# Altium schematic sheet 04_Seven_Seg.SchDoc
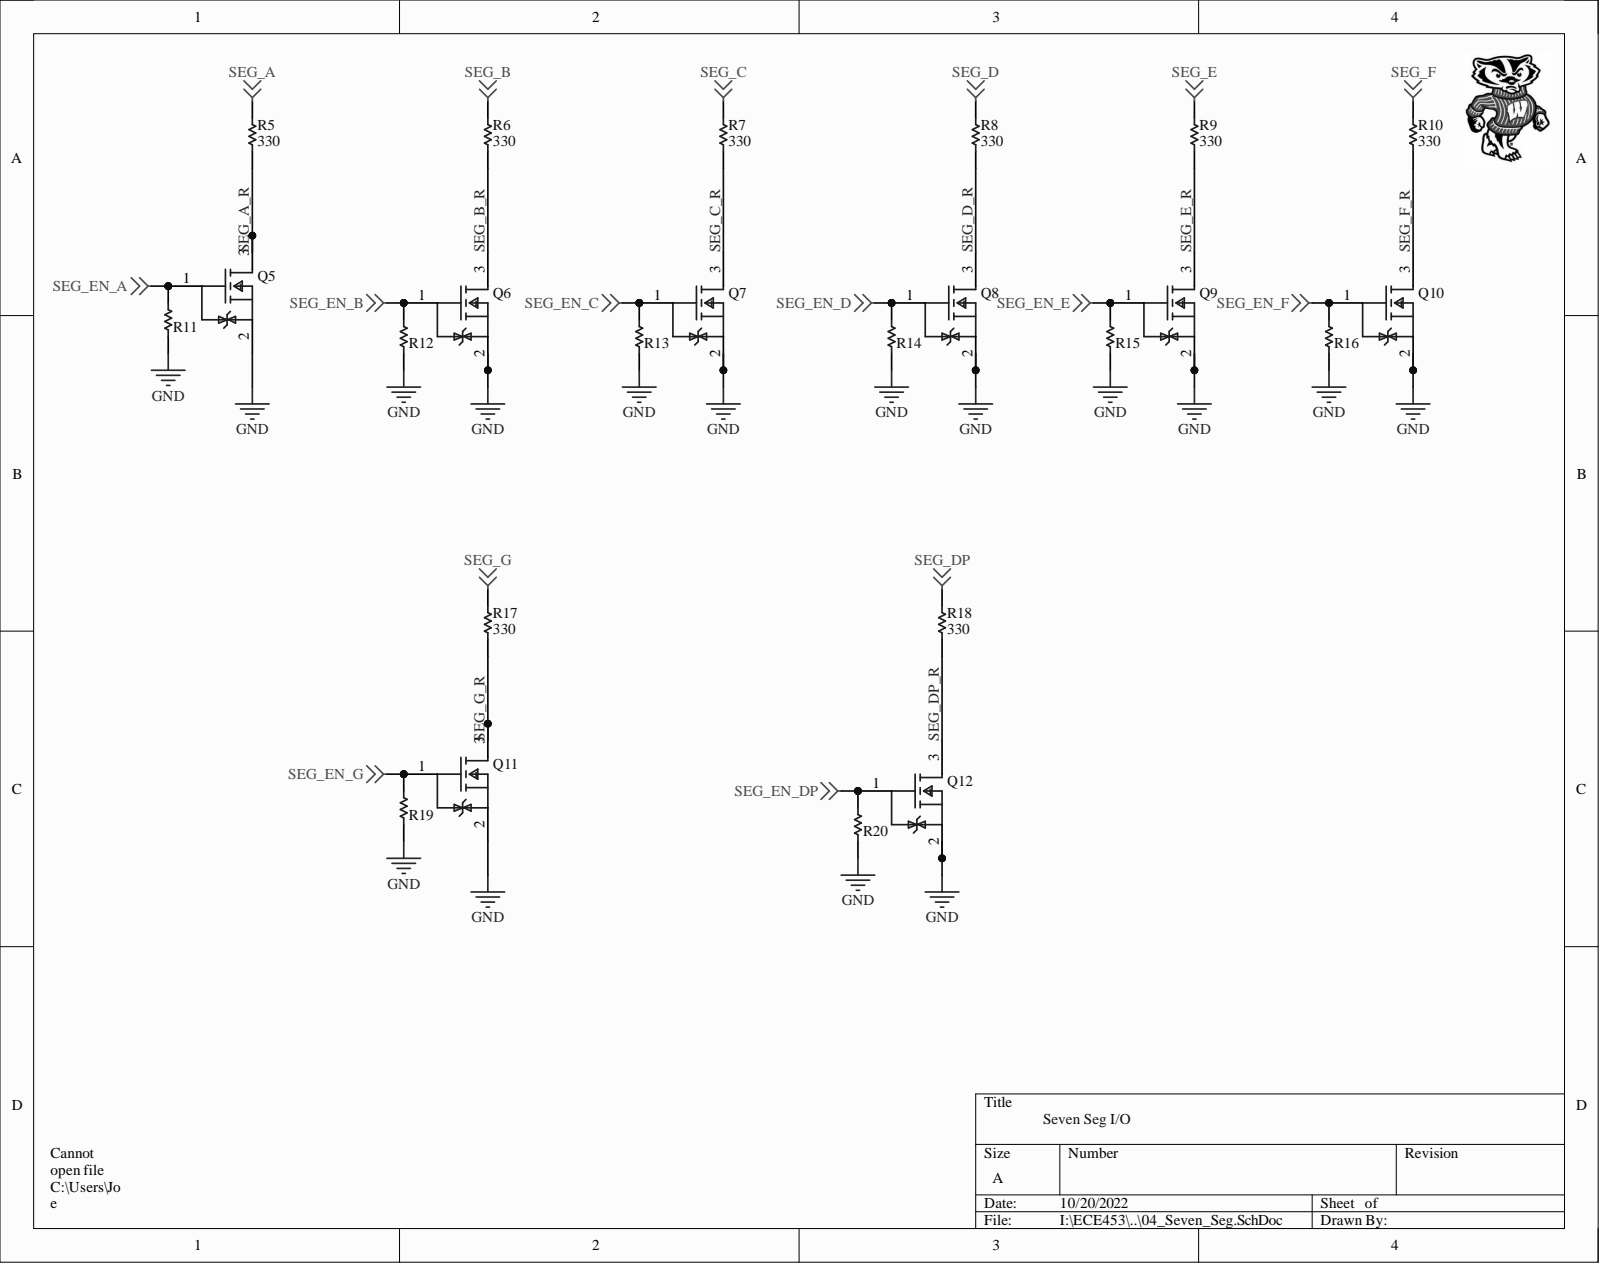
[diagram p0: 04_Seven_Seg.SchDoc]

## Parts
Q5  SSM3K329R,LF  [FP-2-3Z1A-MFG]  (value not drawn)
Q6  SSM3K329R,LF  [FP-2-3Z1A-MFG]  (value not drawn)
Q7  SSM3K329R,LF  [FP-2-3Z1A-MFG]  (value not drawn)
Q8  SSM3K329R,LF  [FP-2-3Z1A-MFG]  (value not drawn)
Q9  SSM3K329R,LF  [FP-2-3Z1A-MFG]  (value not drawn)
Q10  SSM3K329R,LF  [FP-2-3Z1A-MFG]  (value not drawn)
Q11  SSM3K329R,LF  [FP-2-3Z1A-MFG]  (value not drawn)
Q12  SSM3K329R,LF  [FP-2-3Z1A-MFG]  (value not drawn)
R5  330  [RES_0805]
R6  330  [RES_0805]
R7  330  [RES_0805]
R8  330  [RES_0805]
R9  330  [RES_0805]
R10  330  [RES_0805]
R11  RES_0805_K_010.00  [RES_0805]  (value not drawn)
R12  RES_0805_K_010.00  [RES_0805]  (value not drawn)
R13  RES_0805_K_010.00  [RES_0805]  (value not drawn)
R14  RES_0805_K_010.00  [RES_0805]  (value not drawn)
R15  RES_0805_K_010.00  [RES_0805]  (value not drawn)
R16  RES_0805_K_010.00  [RES_0805]  (value not drawn)
R17  330  [RES_0805]
R18  330  [RES_0805]
R19  RES_0805_K_010.00  [RES_0805]  (value not drawn)
R20  RES_0805_K_010.00  [RES_0805]  (value not drawn)

## Nets
GND: Q5.2 Q6.2 Q7.2 Q8.2 Q9.2 Q10.2 Q11.2 Q12.2 R11.1 R12.1 R13.1 R14.1 R15.1 R16.1 R19.1 R20.1
SEG_A: R5.2
SEG_B: R6.2
SEG_C: R7.2
SEG_D: R8.2
SEG_DP: R18.2
SEG_E: R9.2
SEG_EN_A: Q5.1 R11.2
SEG_EN_B: Q6.1 R12.2
SEG_EN_C: Q7.1 R13.2
SEG_EN_D: Q8.1 R14.2
SEG_EN_DP: Q12.1 R20.2
SEG_EN_E: Q9.1 R15.2
SEG_EN_F: Q10.1 R16.2
SEG_EN_G: Q11.1 R19.2
SEG_F: R10.2
SEG_G: R17.2
SEG_A_R: Q5.3 R5.1
SEG_B_R: Q6.3 R6.1
SEG_C_R: Q7.3 R7.1
SEG_D_R: Q8.3 R8.1
SEG_DP_R: Q12.3 R18.1
SEG_E_R: Q9.3 R9.1
SEG_F_R: Q10.3 R10.1
SEG_G_R: Q11.3 R17.1

## Library data of the parts
Q5-Q12 = CMP-35916-000013-1: MOSFET N CH 30V 3.5A 2-3Z1A; Drain to Source Resistance: 290mR; Gate to Source Voltage (Vgs): 12V; Input Capacitance: 123pF; Max Power Dissipation: 1W; Rds On Max: 126mR; Case/Package: SOT-23-3; Component Kind: Standard; Continuous Drain Current (ID): 3.5A; Drain to Source Breakdown Voltage: 30V; Drain to Source Voltage (Vdss): 30V; Library Name: Altium Content Vault; Mount: Surface Mount; Package Quantity: 3000; Packaging: Tape and Reel; Power Dissipation: 1W
R5-R10 R17 R18 = RES_0805_R_330.00: RES 330 OHM 1% 1/8W 0805; Manufacturer 1: Stackpole Electronics; Manufacturer Part Number 1: RMCF0805FT330R; Max Power Dissipation: 125mW; Resistance: 330R; Temperature Coefficient: 100ppm/°C; Case Code (Imperial): 0805; Case Code (Metric): 2012; Case/Package: 0805; Category: Chip SMD Resistors; Component Kind: Standard; ComponentLink1Description: http://components.arrow.com/part/dataview/40963487S9848862N1174?src-supplier=Arrow+Electronics; ComponentLink1URL: http://components.arrow.com/part/dataview/40963487S9848862N1174?src-supplier=Arrow+Electronics; ComponentLink2Description: https://www.seielect.com/catalog/SEI-RMCF_RMCP.pdf?src-supplier=Avnet; ComponentLink2URL: https://www.seielect.com/catalog/SEI-RMCF_RMCP.pdf?src-supplier=Avnet; ComponentLink3Description: https://www.seielect.com/Catalog/SEI-RMCF_RMCP.pdf?src-supplier=Digi-Key; ComponentLink3URL: https://www.seielect.com/Catalog/SEI-RMCF_RMCP.pdf?src-supplier=Digi-Key; ComponentLink4Description: https://www.seielect.com/catalog/SEI-Packaging.pdf?src-supplier=Digi-Key; ComponentLink4URL: https://www.seielect.com/catalog/SEI-Packaging.pdf?src-supplier=Digi-Key; ComponentLink5Description: https://www.verical.com/server-webapp/html/getDatasheet?partID=559884&src-supplier=Verical; ComponentLink5URL: https://www.verical.com/server-webapp/html/getDatasheet?partID=559884&src-supplier=Verical; Construction: Rectangular; Contact Plating: Tin,Matte; Depth: 1.25mm; Features: Automotive AEC-Q200; Height: 0.026inch; Lead Free: Lead Free; Length: 0.079inch; Library Name: team6.SCHLIB; Max Operating Temperature: 155°C; Military Standard: Not; Min Operating Temperature: -55°C; Mount: Surface Mount; Number of Terminations: 2; Packaging: Tape and Reel; Pricing 1: 1=0.10000, 10=0.02700, 100=0.01090, 1000=0.00488, 2500=0.00423 (USD); Radiation Hardening: No; Resistor Type: Thick Film; RoHS: Yes; RoHS Compliant: Yes; Series: RMCF; Stock 1: 205996; Supplier 1: Digi-Key; Supplier Part Number 1: RMCF0805FT330RCT-ND; Tolerance: 1%; Width: 0.049inch
R11-R16 R19 R20 = RES_0805_K_010.00: RES SMD 10K OHM 1% 1/8W 0805; Manufacturer 1: Yageo; Manufacturer Part Number 1: RC0805FR-0710KL; Max Power Dissipation: 125mW; Resistance: 10kR; Temperature Coefficient: 100ppm/°C; Voltage Rating: 150V; Case Code (Imperial): 0805; Case Code (Metric): 2012; Case/Package: 0805; Category: Chip SMD Resistors; Component Kind: Standard; ComponentLink1Description: https://datasheet.ciiva.com/11808/0900766b8106beab-11808151.pdf?src-supplier=RSComponents; ComponentLink1URL: https://datasheet.ciiva.com/11808/0900766b8106beab-11808151.pdf?src-supplier=RSComponents; ComponentLink2Description: https://datasheet.ciiva.com/12669/rc0805-12669688.pdf?src-supplier=Mouser; ComponentLink2URL: https://datasheet.ciiva.com/12669/rc0805-12669688.pdf?src-supplier=Mouser; ComponentLink3Description: https://datasheet.ciiva.com/46447/444164-46447563.pdf?src-supplier=Element14; ComponentLink3URL: https://datasheet.ciiva.com/46447/444164-46447563.pdf?src-supplier=Element14; ComponentLink4Description: https://datasheet.ciiva.com/2456/pyu-r-int-thick-7-2456972.pdf?src-supplier=Digi-Key; ComponentLink4URL: https://datasheet.ciiva.com/2456/pyu-r-int-thick-7-2456972.pdf?src-supplier=Digi-Key; ComponentLink5Description: https://datasheet.ciiva.com/2456/pyu-r-int-thick-7-2456973.pdf?src-supplier=Digi-Key; ComponentLink5URL: https://datasheet.ciiva.com/2456/pyu-r-int-thick-7-2456973.pdf?src-supplier=Digi-Key; ComponentLink6Description: https://datasheet.ciiva.com/2456/pyu-r-int-thick-7-2456974.pdf?src-supplier=Digi-Key; ComponentLink6URL: https://datasheet.ciiva.com/2456/pyu-r-int-thick-7-2456974.pdf?src-supplier=Digi-Key; ComponentLink7Description: https://datasheet.ciiva.com/16747/rc0805-16747087.pdf?src-supplier=Future+Electronics; ComponentLink7URL: https://datasheet.ciiva.com/16747/rc0805-16747087.pdf?src-supplier=Future+Electronics; Construction: Rectangular; Depth: 1.25mm; Features: Moisture Resistant; Height: 0.024inch; Lead Free: Lead Free; Length: 2mm; Library Name: team6.SCHLIB; Max Operating Temperature: 155°C; Military Standard: Not; Min Operating Temperature: -55°C; Number of Terminations: 2; Package Quantity: 1; Packaging: Tape and Reel; Power Rating: 125mW; Pricing 1: 1=0.10000, 10=0.04200, 100=0.01690, 1000=0.00759, 2500=0.00659 (USD); REACH SVHC: Unknown; Resistance Tolerance: 1%; Resistor Type: Thick Film; RoHS: Yes; RoHS Compliant: Yes; Series: RC; Size Code: 0805; Stock 1: 7562122; Supplier 1: Digi-Key; Supplier Part Number 1: 311-10.0KCRCT-ND; Technology: THICK FILM; Termination: SMD/SMT; Tolerance: 1%; Width: 0.049inch
